# Altium schematic sheet InverterSchematic.SchDoc
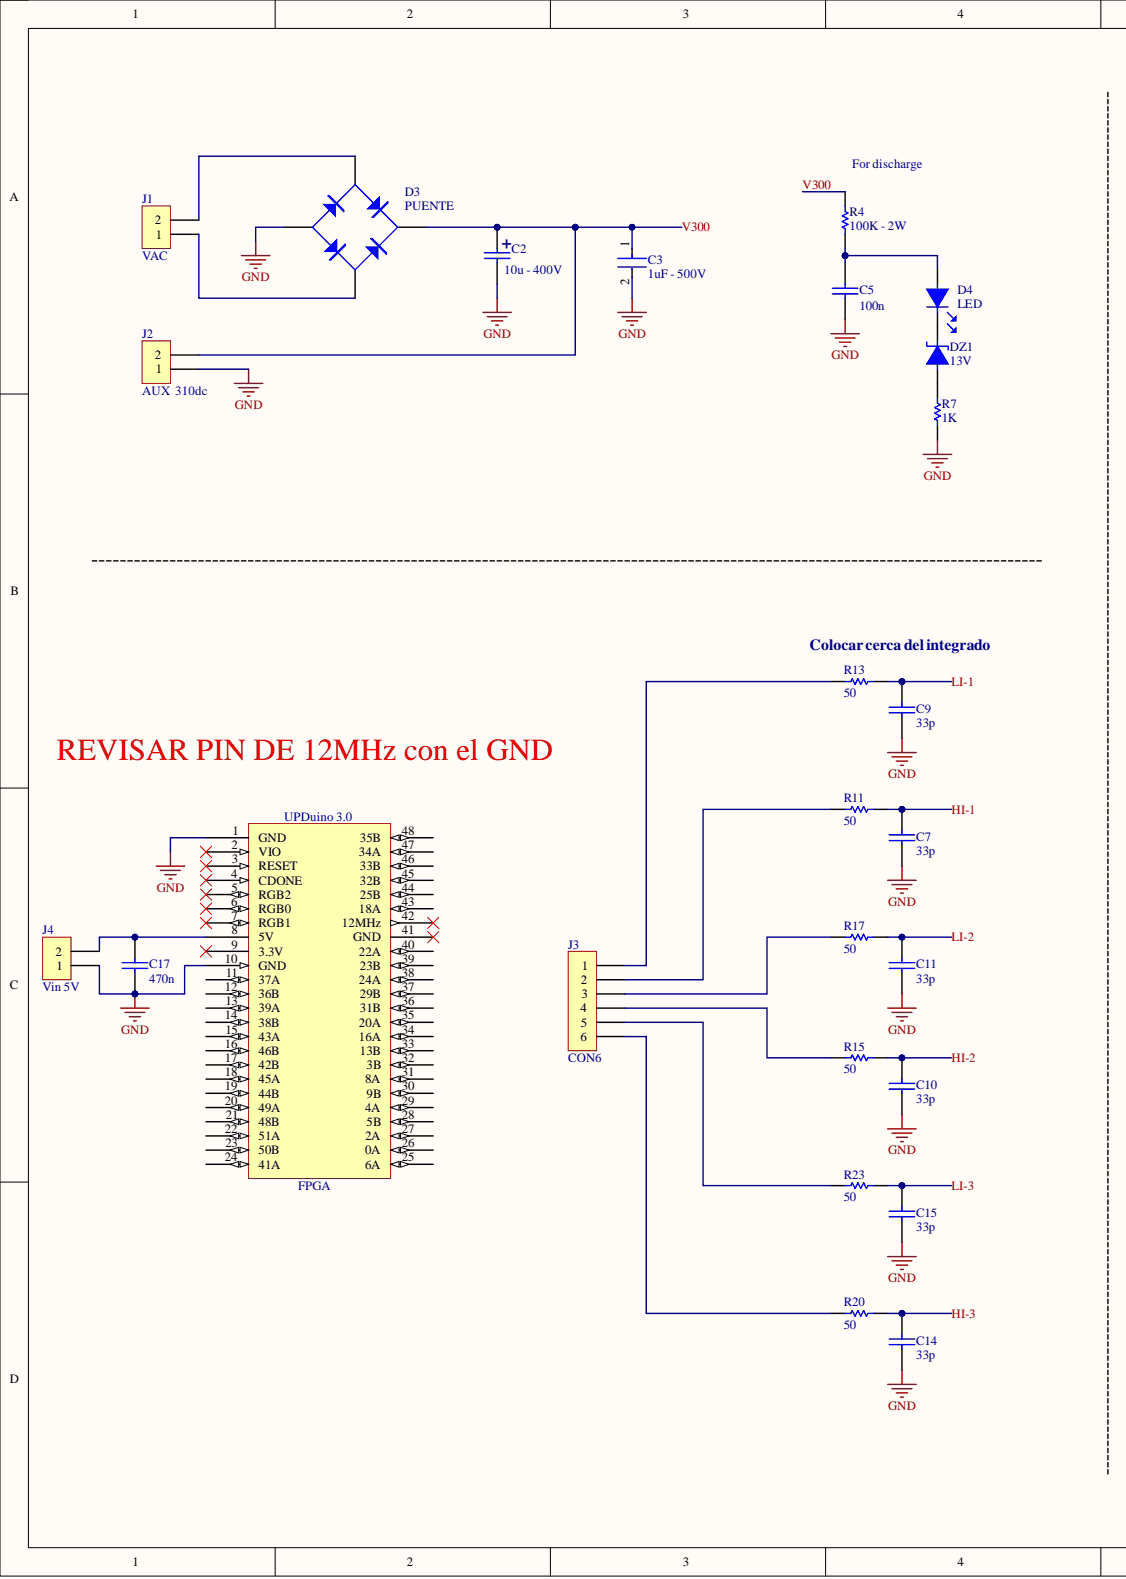
[diagram p0: InverterSchematic.SchDoc, left]
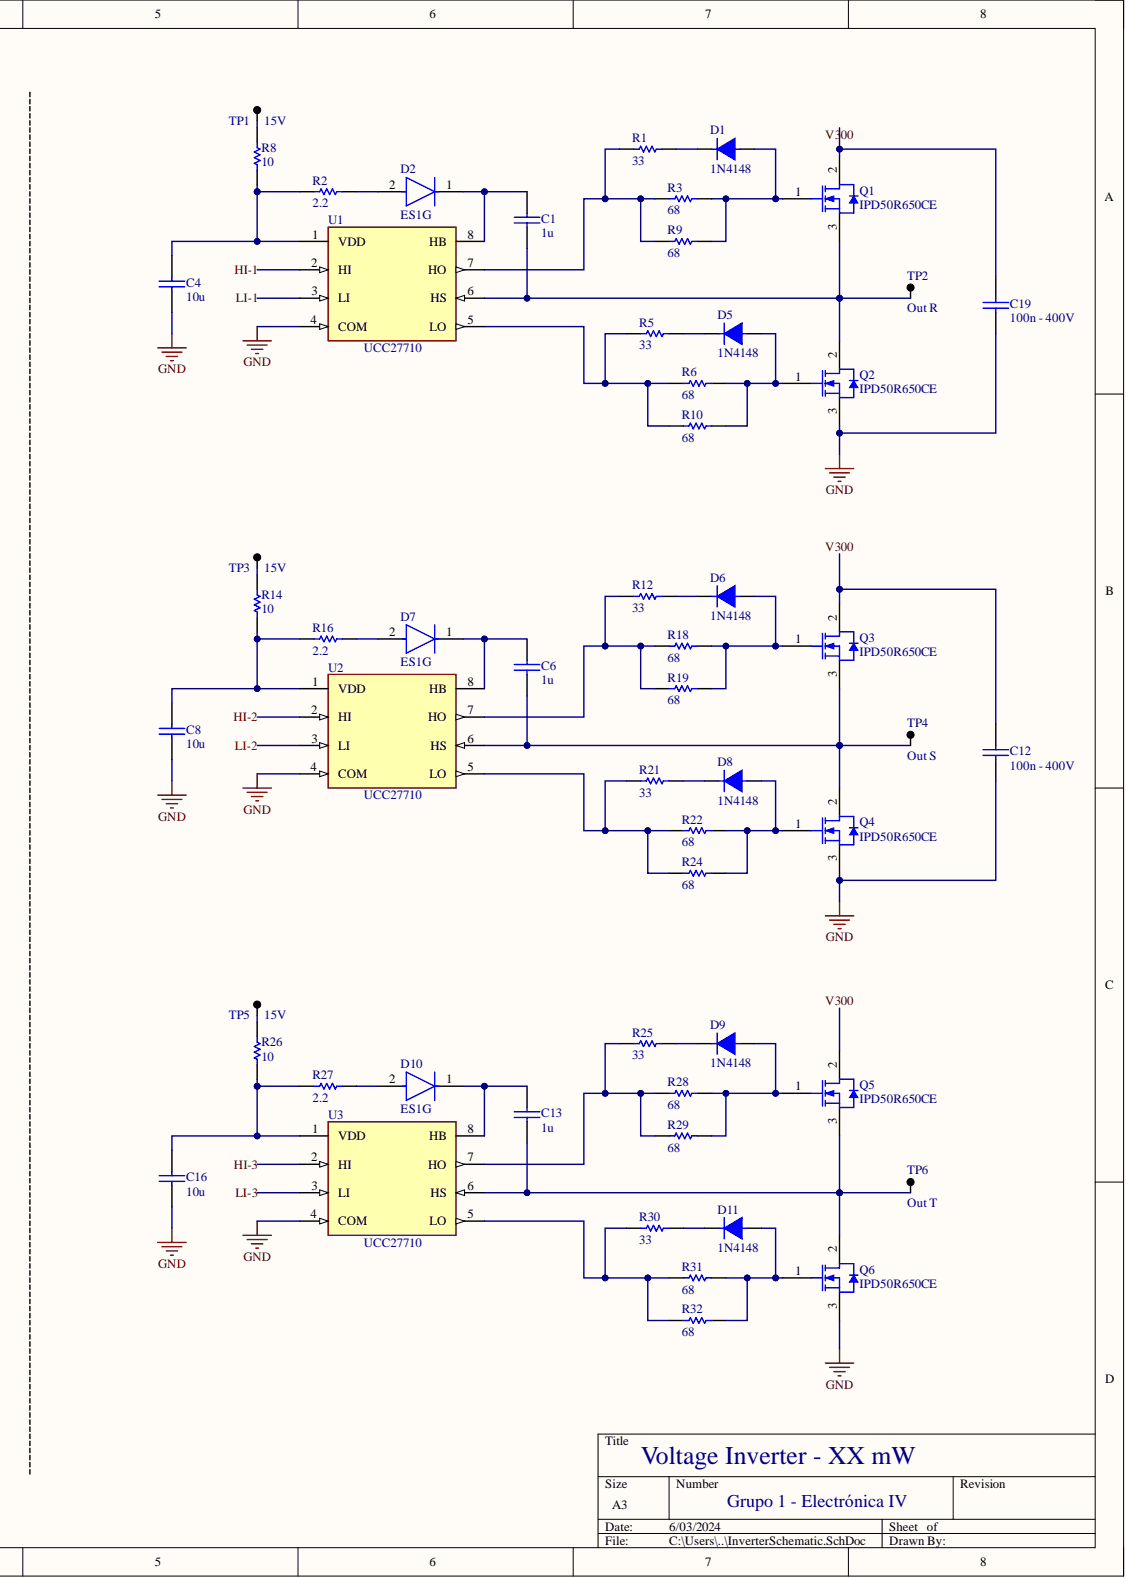
[diagram p1: InverterSchematic.SchDoc, right]

## Parts
C1  1u  [0805]
C2  10u - 400V  [CAP200RP2]
C3  1uF - 500V  Capacitance: 1uF  [FP-GRM55D-0_4-IPC_B]
C4  10u  [0805]
C5  100n  [0805]
C6  1u  [0805]
C7  33p  [CAP100]
C8  10u  [0805]
C9  33p  [CAP100]
C10  33p  [CAP100]
C11  33p  [CAP100]
C12  100n - 400V  [CAP200]
C13  1u  [0805]
C14  33p  [CAP100]
C15  33p  [CAP100]
C16  10u  [0805]
C17  470n  [0805]
C19  100n - 400V  [CAP200]
D1  1N4148  [DO41]
D2  ES1G  [FP-403AE-MFG]
D3  PUENTE  [PUENTE - W10M]
D4  LED  [LED3]
D5  1N4148  [DO41]
D6  1N4148  [DO41]
D7  ES1G  [FP-403AE-MFG]
D8  1N4148  [DO41]
D9  1N4148  [DO41]
D10  ES1G  [FP-403AE-MFG]
D11  1N4148  [DO41]
DZ1  13V  [DO41]
J1  VAC  [BORNERA2]
J2  AUX  310dc  [BORNERA2]
J3  CON6  [SIP6]
J4  Vin 5V  [SIP2]
Q1  IPD50R650CE  [INF-PG-TO252-3-313_N]
Q2  IPD50R650CE  [INF-PG-TO252-3-313_N]
Q3  IPD50R650CE  [INF-PG-TO252-3-313_N]
Q4  IPD50R650CE  [INF-PG-TO252-3-313_N]
Q5  IPD50R650CE  [INF-PG-TO252-3-313_N]
Q6  IPD50R650CE  [INF-PG-TO252-3-313_N]
R1  33  [0805]
R2  2.2  [RES300]
R3  68  [0805]
R4  100K - 2W  [RES300]
R5  33  [0805]
R6  68  [0805]
R7  1K  [0805]
R8  10  [RES300]
R9  68  [0805]
R10  68  [0805]
R11  50  [RES300]
R12  33  [0805]
R13  50  [RES300]
R14  10  [RES300]
R15  50  [RES300]
R16  2.2  [RES300]
R17  50  [RES300]
R18  68  [0805]
R19  68  [0805]
R20  50  [RES300]
R21  33  [0805]
R22  68  [0805]
R23  50  [RES300]
R24  68  [0805]
R25  33  [0805]
R26  10  [RES300]
R27  2.2  [RES300]
R28  68  [0805]
R29  68  [0805]
R30  33  [0805]
R31  68  [0805]
R32  68  [0805]
TP1  15V  [TPTH]
TP2  Out R  [TPTH]
TP3  15V  [TPTH]
TP4  Out S  [TPTH]
TP5  15V  [TPTH]
TP6  Out T  [TPTH]
U1  UCC27710  [FP-PG-DSO-8-IPC_C]
U2  UCC27710  [FP-PG-DSO-8-IPC_C]
U3  UCC27710  [FP-PG-DSO-8-IPC_C]
UPDuino_3.0  FPGA  [FPGA Upduino v3.0]

## Nets
GND: C2.2 C3.2 C4.2 C5.1 C7.2 C8.2 C9.2 C10.2 C11.2 C12.2 C14.2 C15.2 C16.2 C17.2 C19.2 D3.3 J2.1 J4.1 Q2.3 Q4.3 Q6.3 R7.2 U1.4(COM) U2.4(COM) U3.4(COM) UPDuino_3.0.1(GND) UPDuino_3.0.10(GND)
HI-1: C7.1 R11.2 U1.2(HI)
HI-2: C10.1 R15.2 U2.2(HI)
HI-3: C14.1 R20.2 U3.2(HI)
LI-1: C9.1 R13.2 U1.3(LI)
LI-2: C11.1 R17.2 U2.3(LI)
LI-3: C15.1 R23.2 U3.3(LI)
V300: C2.1 C3.1 C12.1 C19.1 D3.1 J2.2 Q1.2 Q3.2 Q5.2 R4.2
NetC1_1: C1.1 D2.1 U1.8(HB)
NetC1_2: C1.2 Q1.3 Q2.2 TP2.1 U1.6(HS)
NetC4_1: C4.1 R2.1 R8.1 U1.1(VDD)
NetC5_2: C5.2 D4.1 R4.1
NetC6_1: C6.1 D7.1 U2.8(HB)
NetC6_2: C6.2 Q3.3 Q4.2 TP4.1 U2.6(HS)
NetC8_1: C8.1 R14.1 R16.1 U2.1(VDD)
NetC13_1: C13.1 D10.1 U3.8(HB)
NetC13_2: C13.2 Q5.3 Q6.2 TP6.1 U3.6(HS)
NetC16_1: C16.1 R26.1 R27.1 U3.1(VDD)
NetC17_1: C17.1 J4.2 UPDuino_3.0.8(5V)
NetD1_1: D1.1 Q1.1 R3.2 R9.2
NetD1_2: D1.2 R1.2
NetD2_2: D2.2 R2.2
NetD3_2: D3.2 J1.2
NetD3_4: D3.4 J1.1
NetD4_2: D4.2 DZ1.2
NetD5_1: D5.1 Q2.1 R6.2 R10.2
NetD5_2: D5.2 R5.2
NetD6_1: D6.1 Q3.1 R18.2 R19.2
NetD6_2: D6.2 R12.2
NetD7_2: D7.2 R16.2
NetD8_1: D8.1 Q4.1 R22.2 R24.2
NetD8_2: D8.2 R21.2
NetD9_1: D9.1 Q5.1 R28.2 R29.2
NetD9_2: D9.2 R25.2
NetD10_2: D10.2 R27.2
NetD11_1: D11.1 Q6.1 R31.2 R32.2
NetD11_2: D11.2 R30.2
NetDZ1_1: DZ1.1 R7.1
NetJ3_1: J3.1 R13.1
NetJ3_2: J3.2 R11.1
NetJ3_3: J3.3 R17.1
NetJ3_4: J3.4 R15.1
NetJ3_5: J3.5 R23.1
NetJ3_6: J3.6 R20.1
NetR1_1: R1.1 R3.1 R9.1 U1.7(HO)
NetR5_1: R5.1 R6.1 R10.1 U1.5(LO)
NetR8_2: R8.2 TP1.1
NetR12_1: R12.1 R18.1 R19.1 U2.7(HO)
NetR14_2: R14.2 TP3.1
NetR21_1: R21.1 R22.1 R24.1 U2.5(LO)
NetR25_1: R25.1 R28.1 R29.1 U3.7(HO)
NetR26_2: R26.2 TP5.1
NetR30_1: R30.1 R31.1 R32.1 U3.5(LO)

Not connected: UPDuino_3.0 2(VIO) 3(RESET) 4(CDONE) 5(RGB2) 6(RGB0) 7(RGB1) 9(3.3V) 11(37A) 12(36B) 13(39A) 14(38B) 15(43A) 16(46B) 17(42B) 18(45A) 19(44B) 20(49A) 21(48B) 22(51A) 23(50B) 24(41A) 25(6A) 26(0A) 27(2A) 28(5B) 29(4A) 30(9B) 31(8A) 32(3B) 33(13B) 34(16A) 35(20A) 36(31B) 37(29B) 38(24A) 39(23B) 40(22A) 41(GND) 42(12MHz) 43(18A) 44(25B) 45(32B) 46(33B) 47(34A) 48(35B)

## Library data of the parts
C1 C4-C17 C19 = CAP: Component Kind: Standard
  C1 C4 C6-C17 C19: Library Name: LIBEBAL.IntLib
  C5: Library Name: LIBEBAL Tobi.IntLib
C2 = CAPPOL: Component Kind: Standard; Library Name: LIBEBAL Tobi.IntLib
C3 = CMP-2000-06370-2: Chip Multilayer Ceramic Capacitors for General Purpose, 2220, 1.0uF, X7R, 15%, 10%, 500V; Voltage: 250V; Case Code (Imperial): 2220; Case Code (Metric): 5750; Case/Package: 2220; Component Kind: Standard; Depth: 5mm; Dielectric: X7R; Height: 2mm; Lead Free: Lead Free; Length: 5.7mm; Library Name: Altium Content Vault; Material: Ceramic; Max Operating Temperature: 125°C; Min Operating Temperature: -55°C; Mount: Surface Mount; Number of Pins: 2; Package Quantity: 1; Packaging: Tape and Reel; REACH SVHC: No SVHC; Termination: SMD/SMT; Thickness: 0.087inch; Tolerance: 10%; Voltage Rating: 250V; Voltage Rating (DC): 250V; Width: 5mm
D1 D5 D6 D8 D9 D11 = DIODO: Component Kind: Standard; Library Name: LIBEBAL.IntLib
D2 D7 D10 = CMP-07163-000025-1: DIODE GEN PURP 400V 1A SMA; Manufacturer: ON Semiconductor; Capacitance: 10pF; Max Junction Temp: +150°C; Max Surge Forward Current: 30A; Peak Reverse Current: 5uA; Standoff Height: 0.05mm; Value: 10pF; Automotive: No; Category: Diode; Component Kind: Standard; ComponentLink2Description: Datasheet; ComponentLink2URL: https://www.onsemi.com/pub/Collateral/ES1J-D.PDF; Device Class L1: Discrete Semiconductors; Device Class L2: Diodes; Device Class L3: Rectifier Diodes; Digikey Description: DIODE GEN PURP 400V 1A SMA; Footprint Url: https://www.onsemi.com/pub/Collateral/403AE.PDF; Forward Current: 1A; Height: 2.5mm; Lead Free: Yes; Library Name: Altium Content Vault; Manufacturer Part Number: ES1G; Max Repetitive Reverse Voltage: 400V; Mouser Description: Rectifiers 1.0 A Ultra Fast Recovery Rect; Octopart: https://octopart.com/es1g-on+semiconductor-84324858; Package: 403AE; RoHS: TRUE; Temperature: to +150°C; Temperature Range High: +150°C
DZ1 = DIODO ZENER: Component Kind: Standard; Library Name: LIBEBAL Tobi.IntLib
J1 J2 = BORNERA 2: Component Kind: Standard; Library Name: LIBEBAL Tobi.IntLib
J4 = CON2: UPDuino Power Input; Component Kind: Standard; Library Name: LIBEBAL Tobi.IntLib
Q1-Q6 = CMP-1164-00335-1: N-Channel CoolMOS CE Power-Transistor, 500 V VDS, 6.1 A ID, -55 to 150 degC, PG-TO252-3-313, Reel, Green; Manufacturer: Infineon; Green: TRUE; ID (max): 6.1Â A; IDpuls (max): 19Â A; Polarity: N; Ptot (max): 47Â W; QG: 15Â nC; RDS (on) (max): 650Â mOhm; Rth: 2.67Â K/W; RthJA (max): 62Â K/W; VDS (max): 500Â V; VGS(th) (max): 3.5Â V; VGS(th) (min): 2.5Â V; Component Kind: Standard; ComponentLink1Description: Manufacturer URL; ComponentLink1URL: http://www.infineon.com/; ComponentLink2Description: Datasheet; ComponentLink2URL: http://www.infineon.com/dgdl/IPD50R650CE+2.0.pdf?folderId=db3a3043156fd5730115c736bcc70ff2&fileId=db3a304339d29c450139d41341a202a1; ComponentLink3Description: Package Specification; ComponentLink3URL: http://www.infineon.com/dgdl/PG-TO252-3-313-Package_Overview.pdf?folderId=db3a30432b57a660012b81890ade72d2&fileId=db3a30432b57a660012b8193af2872eb; DatasheetVersion: Rev. 2.0; Library Name: Altium Content Vault; Mounting Technology: Surface Mount; PackageDescription: 3-Pin Plastic (Green) Transistor Single Outline Package, 6.5 x 6.12 mm Body, 2.28 mm Pitch; PackageReference: PG-TO252-3-313; PackageVersion: Oct-10; Packing: Reel; PartNumber: IPD50R650CE; RthJC (max): 2.67Â K/W; Technology: CoolMOS CE
R1 R12 R25 = RES: 33?; Component Kind: Standard; Library Name: LIBEBAL.IntLib
R2-R11 R13-R24 R26-R32 = RES: Component Kind: Standard
  R2 R3 R5-R11 R13-R24 R26-R32: Library Name: LIBEBAL.IntLib
  R4: Library Name: LIBEBAL Tobi.IntLib
TP1-TP6 = TP: Component Kind: Standard; Library Name: LIBEBAL.IntLib
U1-U3 = CMP-32851-000209-1: GATE DRVR HI/LOW SIDE 8SOIC; Channel Type: Independent; Fall Time: 90ns; Max Output Current: 600mA; Max Supply Voltage: 20V; Min Supply Voltage: 10V; Number of Channels: 2; Output Voltage: 620V; Propagation Delay: 160ns; Case/Package: SOIC; Component Kind: Standard; Halogen Free: Halogen Free; Height: 0.059inch; Lead Free: Lead Free; Length: 0.196inch; Library Name: Altium Content Vault; Max Operating Temperature: 150°C; Min Operating Temperature: -40°C; Mount: Surface Mount; Number of Drivers: 2; Number of Outputs: 2; Number of Pins: 8; Output Current: 600mA; Package Quantity: 95; Packaging: Rail/Tube; Reach SVHC Compliant: Yes; RoHS Compliant: Yes; Series: -; Width: 0.157inch
D3 = PUENTE: Component Kind: Standard; Library Name: LIBEBAL Tobi.IntLib
D4 = LED: Component Kind: Standard; Library Name: LIBEBAL Tobi.IntLib
J3 = CON6: Component Kind: Standard; Library Name: LIBEBAL.IntLib
UPDuino_3.0 = FPGA: Component Kind: Standard; Library Name: InverterSchLib.SchLib
